# Altium schematic sheet peripherals.SchDoc
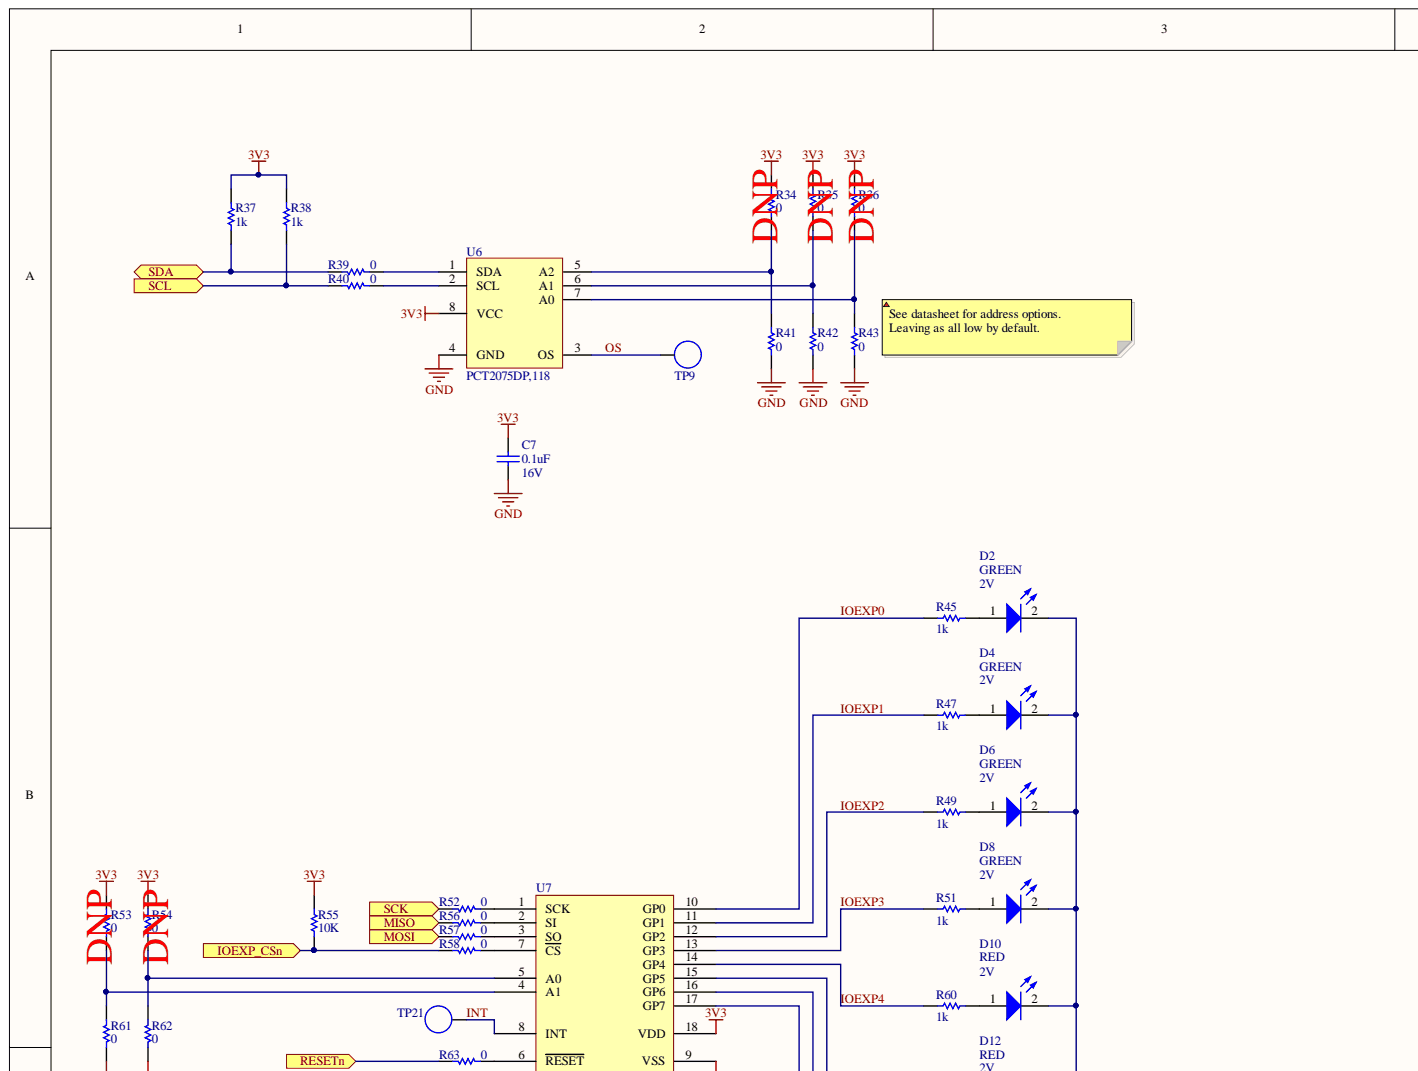
[diagram p0: peripherals.SchDoc, top left]
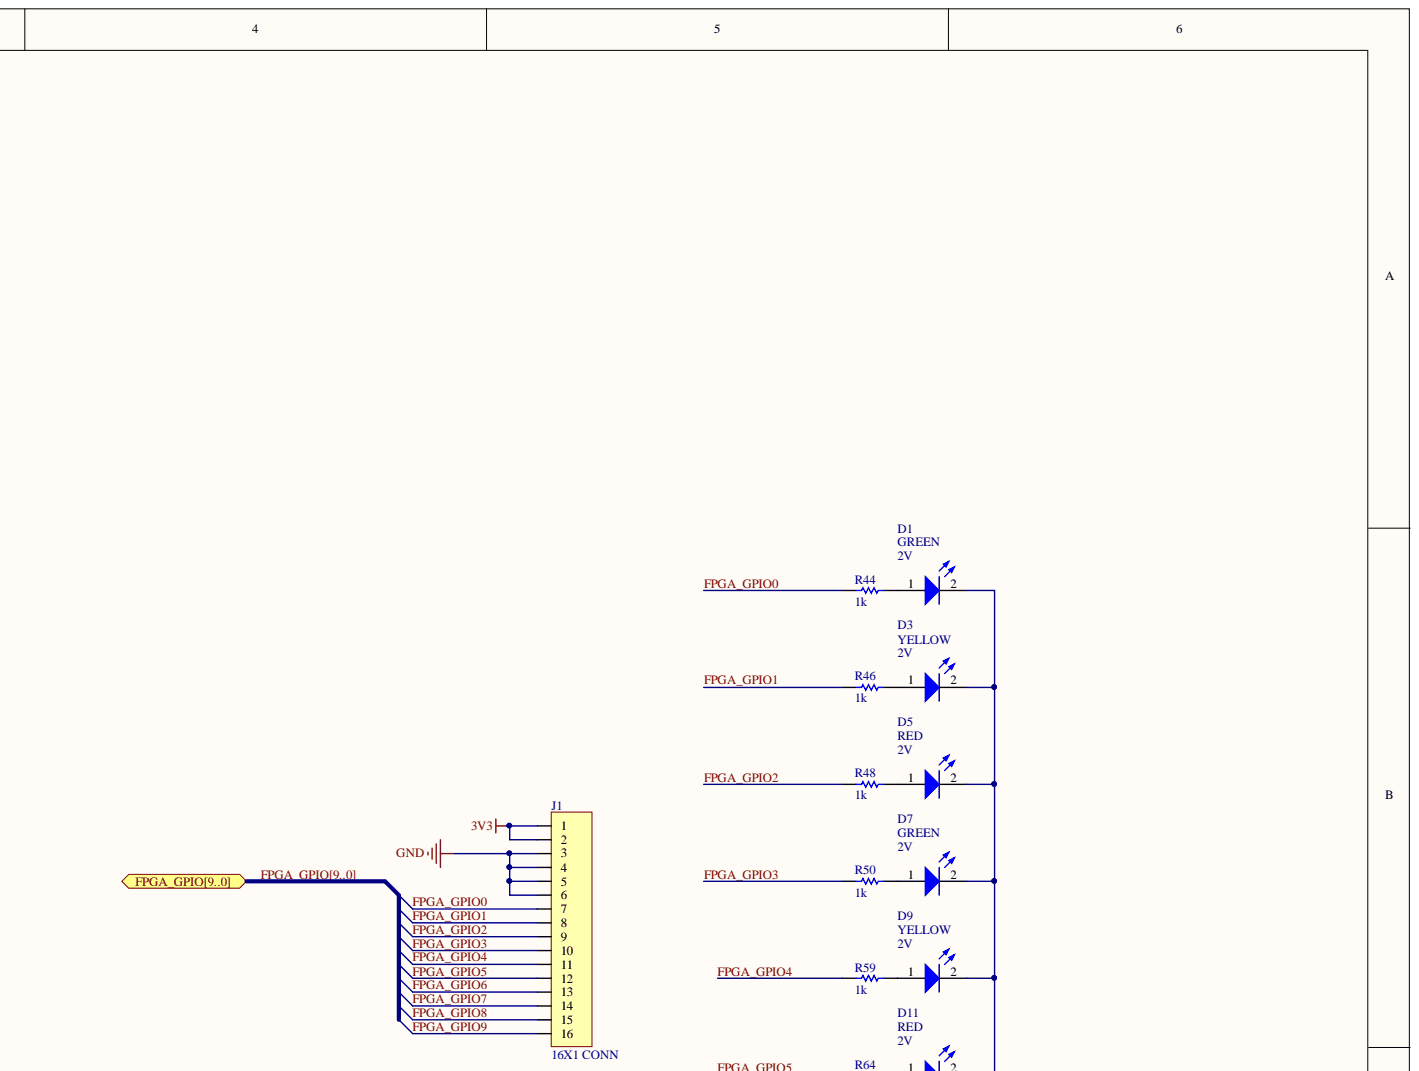
[diagram p1: peripherals.SchDoc, top right]
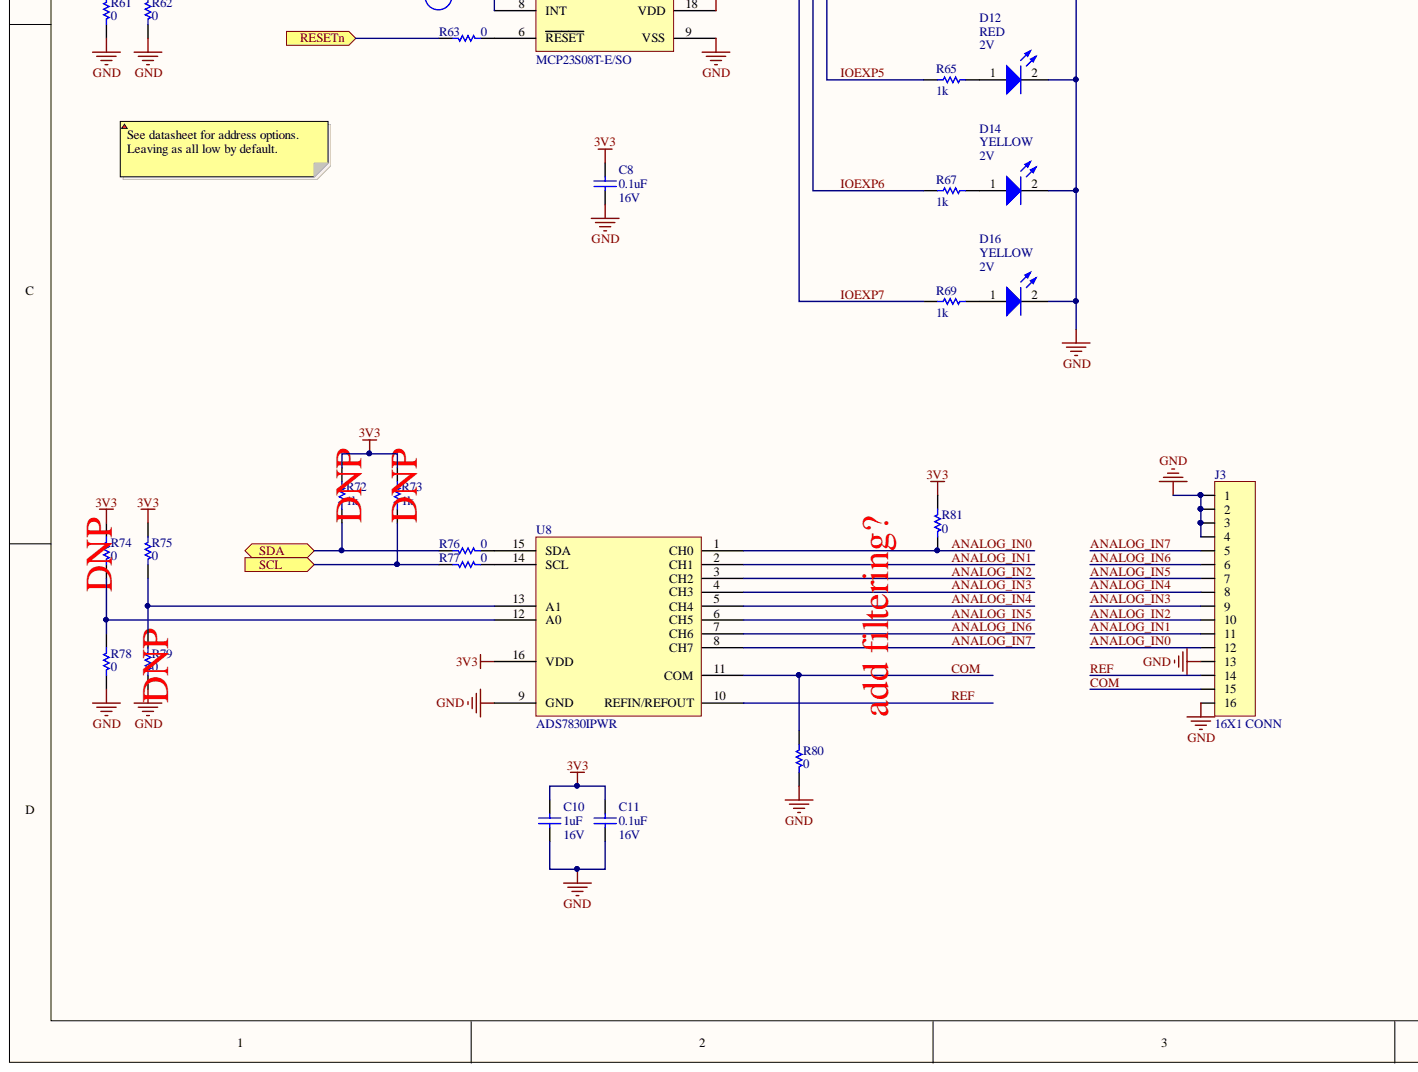
[diagram p2: peripherals.SchDoc, bottom left]
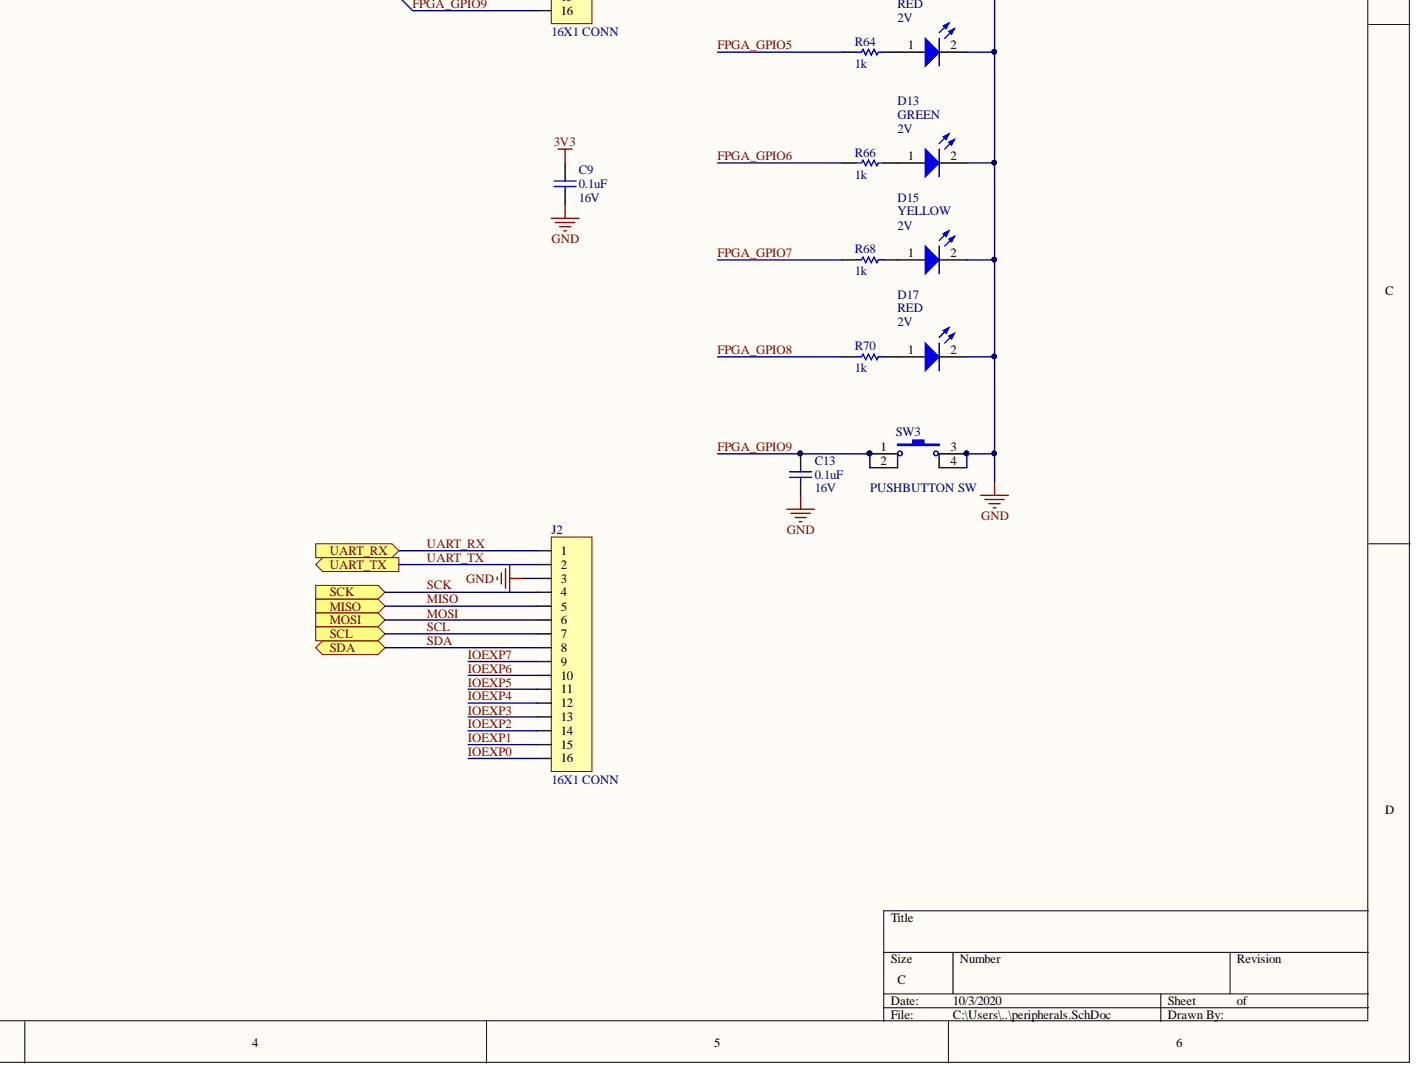
[diagram p3: peripherals.SchDoc, bottom right]

## Parts
C7  Capacitance: 0.1uF  Voltage: 16V  [0805]  (hidden comment: Cap_NP)
C8  Capacitance: 0.1uF  Voltage: 16V  [0805]  (hidden comment: Cap_NP)
C9  Capacitance: 0.1uF  Voltage: 16V  [0805]  (hidden comment: Cap_NP)
C10  Capacitance: 1uF  Voltage: 16V  [0805]  (hidden comment: Cap_NP)
C11  Capacitance: 0.1uF  Voltage: 16V  [0805]  (hidden comment: Cap_NP)
C13  Capacitance: 0.1uF  Voltage: 16V  [0805]  (hidden comment: Cap_NP)
D1  Color: GREEN  Vf: 2V  [0805]  (hidden comment: LED)
D2  Color: GREEN  Vf: 2V  [0805]  (hidden comment: LED)
D3  Color: YELLOW  Vf: 2V  [0805]  (hidden comment: LED)
D4  Color: GREEN  Vf: 2V  [0805]  (hidden comment: LED)
D5  Color: RED  Vf: 2V  [0805]  (hidden comment: LED)
D6  Color: GREEN  Vf: 2V  [0805]  (hidden comment: LED)
D7  Color: GREEN  Vf: 2V  [0805]  (hidden comment: LED)
D8  Color: GREEN  Vf: 2V  [0805]  (hidden comment: LED)
D9  Color: YELLOW  Vf: 2V  [0805]  (hidden comment: LED)
D10  Color: RED  Vf: 2V  [0805]  (hidden comment: LED)
D11  Color: RED  Vf: 2V  [0805]  (hidden comment: LED)
D12  Color: RED  Vf: 2V  [0805]  (hidden comment: LED)
D13  Color: GREEN  Vf: 2V  [0805]  (hidden comment: LED)
D14  Color: YELLOW  Vf: 2V  [0805]  (hidden comment: LED)
D15  Color: YELLOW  Vf: 2V  [0805]  (hidden comment: LED)
D16  Color: YELLOW  Vf: 2V  [0805]  (hidden comment: LED)
D17  Color: RED  Vf: 2V  [0805]  (hidden comment: LED)
J1  16X1 CONN  [0.100 16X1 HEADER]
J2  16X1 CONN  [0.100 16X1 HEADER]
J3  16X1 CONN  [0.100 16X1 HEADER]
R34  Res  [0805]  (value not drawn)
R35  Res  [0805]  (value not drawn)
R36  Res  [0805]  (value not drawn)
R37  Resistance: 1k  [0805]  (hidden comment: Res)
R38  Resistance: 1k  [0805]  (hidden comment: Res)
R39  Res  [0805]  (value not drawn)
R40  Res  [0805]  (value not drawn)
R41  Res  [0805]  (value not drawn)
R42  Res  [0805]  (value not drawn)
R43  Res  [0805]  (value not drawn)
R44  Resistance: 1k  [0805]  (hidden comment: Res)
R45  Resistance: 1k  [0805]  (hidden comment: Res)
R46  Resistance: 1k  [0805]  (hidden comment: Res)
R47  Resistance: 1k  [0805]  (hidden comment: Res)
R48  Resistance: 1k  [0805]  (hidden comment: Res)
R49  Resistance: 1k  [0805]  (hidden comment: Res)
R50  Resistance: 1k  [0805]  (hidden comment: Res)
R51  Resistance: 1k  [0805]  (hidden comment: Res)
R52  Res  [0805]  (value not drawn)
R53  Res  [0805]  (value not drawn)
R54  Res  [0805]  (value not drawn)
R55  Resistance: 10K  [0805]  (hidden comment: Res)
R56  Res  [0805]  (value not drawn)
R57  Res  [0805]  (value not drawn)
R58  Res  [0805]  (value not drawn)
R59  Resistance: 1k  [0805]  (hidden comment: Res)
R60  Resistance: 1k  [0805]  (hidden comment: Res)
R61  Res  [0805]  (value not drawn)
R62  Res  [0805]  (value not drawn)
R63  Res  [0805]  (value not drawn)
R64  Resistance: 1k  [0805]  (hidden comment: Res)
R65  Resistance: 1k  [0805]  (hidden comment: Res)
R66  Resistance: 1k  [0805]  (hidden comment: Res)
R67  Resistance: 1k  [0805]  (hidden comment: Res)
R68  Resistance: 1k  [0805]  (hidden comment: Res)
R69  Resistance: 1k  [0805]  (hidden comment: Res)
R70  Resistance: 1k  [0805]  (hidden comment: Res)
R72  Resistance: 1k  [0805]  (hidden comment: Res)
R73  Resistance: 1k  [0805]  (hidden comment: Res)
R74  Res  [0805]  (value not drawn)
R75  Res  [0805]  (value not drawn)
R76  Res  [0805]  (value not drawn)
R77  Res  [0805]  (value not drawn)
R78  Res  [0805]  (value not drawn)
R79  Res  [0805]  (value not drawn)
R80  Res  [0805]  (value not drawn)
R81  Res  [0805]  (value not drawn)
SW3  PUSHBUTTON SW  [PTS645SM43SMTR92 LFS]
TP9  TP  [KEYSTONE_5012]
TP21  TP  [KEYSTONE_5012]
U6  PCT2075DP,118  [NXP TSSOP-8 (SOT505-1)]
U7  MCP23S08T-E/SO  [MICROCHIP 18-SOIC]
U8  ADS7830IPWR  [TI PW0016A (16TSSOP)]

## Ports
FPGA_GPIO0, FPGA_GPIO1, FPGA_GPIO2, FPGA_GPIO3, FPGA_GPIO4, FPGA_GPIO5, FPGA_GPIO6, FPGA_GPIO7, FPGA_GPIO8, FPGA_GPIO9, FPGA_GPIO[9..0], IOEXP_CSn, MISO, MOSI, RESETn, SCK, SCL, SDA, UART_RX, UART_TX

## Nets
3V3: C7.2 C8.2 C9.2 C10.2 C11.2 J1.1 J1.2 R34.1 R35.1 R36.1 R37.1 R38.1 R53.1 R54.1 R55.1 R72.1 R73.1 R74.1 R75.1 R81.1 U6.8(VCC) U7.18(VDD) U8.16(VDD)
GND: C7.1 C8.1 C9.1 C10.1 C11.1 C13.1 D1.2 D2.2 D3.2 D4.2 D5.2 D6.2 D7.2 D8.2 D9.2 D10.2 D11.2 D12.2 D13.2 D14.2 D15.2 D16.2 D17.2 J1.3 J1.4 J1.5 J1.6 J2.3 J3.1 J3.2 J3.3 J3.4 J3.13 J3.16 R41.2 R42.2 R43.2 R61.2 R62.2 R78.2 R79.2 R80.2 SW3.3 SW3.4 U6.4(GND) U7.9(VSS) U8.9(GND)
ANALOG_IN0: J3.12 R81.2 U8.1(CH0)
ANALOG_IN1: J3.11 U8.2(CH1)
ANALOG_IN2: J3.10 U8.3(CH2)
ANALOG_IN3: J3.9 U8.4(CH3)
ANALOG_IN4: J3.8 U8.5(CH4)
ANALOG_IN5: J3.7 U8.6(CH5)
ANALOG_IN6: J3.6 U8.7(CH6)
ANALOG_IN7: J3.5 U8.8(CH7)
COM: J3.15 R80.1 U8.11(COM)
FPGA_GPIO0: J1.7 R44.2 ; port FPGA_GPIO[9..0]  [also labelled FPGA_GPIO]
FPGA_GPIO1: J1.8 R46.2 ; port FPGA_GPIO[9..0]  [also labelled FPGA_GPIO]
FPGA_GPIO2: J1.9 R48.2 ; port FPGA_GPIO[9..0]  [also labelled FPGA_GPIO]
FPGA_GPIO3: J1.10 R50.2 ; port FPGA_GPIO[9..0]  [also labelled FPGA_GPIO]
FPGA_GPIO4: J1.11 R59.2 ; port FPGA_GPIO[9..0]  [also labelled FPGA_GPIO]
FPGA_GPIO5: J1.12 R64.2 ; port FPGA_GPIO[9..0]  [also labelled FPGA_GPIO]
FPGA_GPIO6: J1.13 R66.2 ; port FPGA_GPIO[9..0]  [also labelled FPGA_GPIO]
FPGA_GPIO7: J1.14 R68.2 ; port FPGA_GPIO[9..0]  [also labelled FPGA_GPIO]
FPGA_GPIO8: J1.15 R70.2 ; port FPGA_GPIO[9..0]  [also labelled FPGA_GPIO]
FPGA_GPIO9: C13.2 J1.16 SW3.1 SW3.2 ; port FPGA_GPIO[9..0]  [also labelled FPGA_GPIO]
INT: TP21.1 U7.8(INT)
IOEXP0: J2.16 R45.2 U7.10(GP0)
IOEXP1: J2.15 R47.2 U7.11(GP1)
IOEXP2: J2.14 R49.2 U7.12(GP2)
IOEXP3: J2.13 R51.2 U7.13(GP3)
IOEXP4: J2.12 R60.2 U7.14(GP4)
IOEXP5: J2.11 R65.2 U7.15(GP5)
IOEXP6: J2.10 R67.2 U7.16(GP6)
IOEXP7: J2.9 R69.2 U7.17(GP7)
IOEXP_CSn: R55.2 R58.2 ; port IOEXP_CSn
MISO: J2.5 R56.2 ; port MISO
MOSI: J2.6 R57.2 ; port MOSI
OS: TP9.1 U6.3(OS)
REF: J3.14 U8.10(REFIN/REFOUT)
RESETn: R63.2 ; port RESETn
SCK: J2.4 R52.2 ; port SCK
SCL: J2.7 R38.2 R40.2 R73.2 R77.2 ; port SCL
SDA: J2.8 R37.2 R39.2 R72.2 R76.2 ; port SDA
UART_RX: J2.1 ; port UART_RX
UART_TX: J2.2 ; port UART_TX
NetD1_1: D1.1 R44.1
NetD2_1: D2.1 R45.1
NetD3_1: D3.1 R46.1
NetD4_1: D4.1 R47.1
NetD5_1: D5.1 R48.1
NetD6_1: D6.1 R49.1
NetD7_1: D7.1 R50.1
NetD8_1: D8.1 R51.1
NetD9_1: D9.1 R59.1
NetD10_1: D10.1 R60.1
NetD11_1: D11.1 R64.1
NetD12_1: D12.1 R65.1
NetD13_1: D13.1 R66.1
NetD14_1: D14.1 R67.1
NetD15_1: D15.1 R68.1
NetD16_1: D16.1 R69.1
NetD17_1: D17.1 R70.1
NetR34_2: R34.2 R41.1 U6.5(A2)
NetR35_2: R35.2 R42.1 U6.6(A1)
NetR36_2: R36.2 R43.1 U6.7(A0)
NetR39_1: R39.1 U6.1(SDA)
NetR40_1: R40.1 U6.2(SCL)
NetR52_1: R52.1 U7.1(SCK)
NetR53_2: R53.2 R61.1 U7.4(A1)
NetR54_2: R54.2 R62.1 U7.5(A0)
NetR56_1: R56.1 U7.2(SI)
NetR57_1: R57.1 U7.3(SO)
NetR58_1: R58.1 U7.7(CS)
NetR63_1: R63.1 U7.6(RESET)
NetR74_2: R74.2 R78.1 U8.12(A0)
NetR75_2: R75.2 R79.1 U8.13(A1)
NetR76_1: R76.1 U8.15(SDA)
NetR77_1: R77.1 U8.14(SCL)

## Library data of the parts
C7-C9 C11 C13 = Cap_NP: Capacitor; Manufacturer: KEMET; Manufacturer PN: C0805C104M4VACTU; Component Kind: Standard; Library Name: symbols.SchLib
C10 = Cap_NP: Capacitor; Manufacturer: Taiyo Yuden; Manufacturer PN: EMK212BJ105MD-T; Component Kind: Standard; Library Name: symbols.SchLib
D1 D2 D4 D6-D8 D13 = LED: Manufacturer: Lite-On; Manufacturer PN: LTST-C171KGKT; Component Kind: Standard; Library Name: symbols.SchLib
D3 D9 D14-D16 = LED: Manufacturer: Lite-On; Manufacturer PN: LTST-C171KSKT; Component Kind: Standard; Library Name: symbols.SchLib
D5 D10-D12 D17 = LED: Manufacturer: Lite-On; Manufacturer PN: LTST-C171KRKT; Component Kind: Standard; Library Name: symbols.SchLib
J1-J3 = 16X1 CONN: Manufacturer: Sullins; Manufacturer PN: PPTC161LFBN-RC; Component Kind: Standard; Library Name: symbols.SchLib
R34-R36 R39-R43 R52-R54 R56-R58 R61-R63 R74-R81 = Res: Resistor; Manufacturer: Stackpole Electronics Inc; Manufacturer PN: RMCF0805ZT0R00; Power Rating: 1/10W; Resistance: 0; Tolerance: Jumper; Component Kind: Standard; Library Name: symbols.SchLib
R37 R38 R44-R51 R59 R60 R64-R70 R72 R73 = Res: Resistor; Manufacturer: Stackpole Electronics Inc; Manufacturer PN: RMCF0805JT1K00; Power Rating: 1/8W; Tolerance: 5%; Component Kind: Standard; Library Name: symbols.SchLib
R55 = Res: Resistor; Manufacturer: Stackpole Electronics Inc; Manufacturer PN: RMCF0805JT10K0; Power Rating: 1/8W; Tolerance: 5%; Component Kind: Standard; Library Name: symbols.SchLib
SW3 = SWITCH_PB: Manufacturer: C&K; Component Kind: Standard; Library Name: symbols.SchLib; Manufacturer PN: PTS645SM43SMTR92 LFS
TP9 TP21 = TP: Manufacturer: Keystone; Manufacturer PN: 5015; Component Kind: Standard; Library Name: symbols.SchLib
U6 = PCT2075DP,118: Temperature Sensor Digital, Local -55°C ~ 125°C 11 b 8-TSSOP; Manufacturer: NXP; Component Kind: Standard; Library Name: symbols.SchLib; Manufacturer PN: PCT2075DP,118
U7 = MCP23S08T-E/SO: Manufacturer: Microchip; Component Kind: Standard; Library Name: symbols.SchLib; Manufacturer PN: MCP23S08T-E/SO
U8 = ADS7830IPWR: Manufacturer: Texas Instruments; Component Kind: Standard; Library Name: symbols.SchLib; Manufacturer PN: ADS7830IPWR
